# Altium schematic sheet VCU_Schematic.SchDoc(VCU_Schematic)
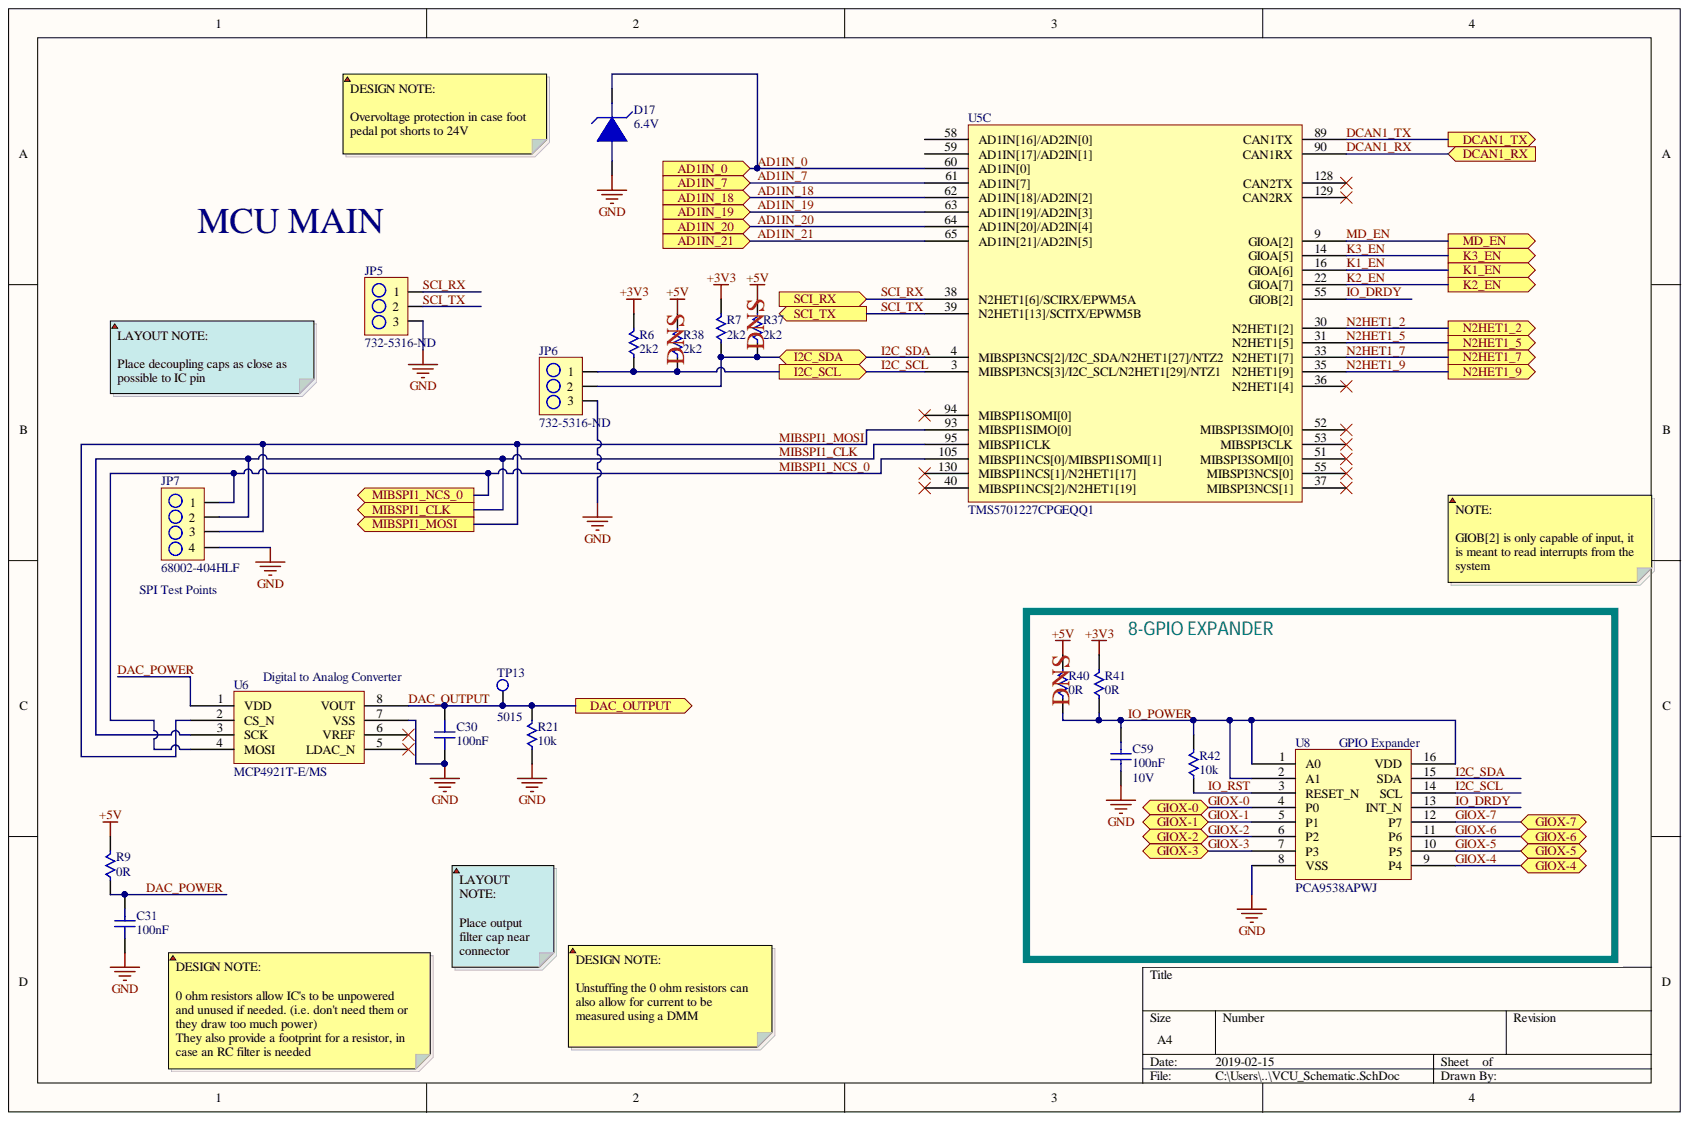
[diagram p0: VCU_Schematic.SchDoc]

## Parts
C30  100nF  [C0402]
C31  100nF  [C0402]
C59  100nF  Voltage Rating (DC): 10V  [C0402]
D17  6.4V  [DIODE_TVS]
JP5  732-5316-ND  [3PIN_HEADER]
JP6  732-5316-ND  [3PIN_HEADER]
JP7  68002-404HLF  [4PIN_HEADER]
R6  2k2  [R0402]
R7  2k2  [R0402]
R9  0R  [R0402]
R21  10k  [R0402]
R37  2k2  [R0402]
R38  2k2  [R0402]
R40  0R  [R0402]
R41  0R  [R0402]
R42  10k  [R0402]
TP13  5015  [TP_HOOK]
U5  TMS5701227CPGEQQ1  [LQFP-144]  (part C)
U6  MCP4921T-E/MS  [8-MSOP_MCP4921]
U8  PCA9538APWJ  [TSSOP-16]

## Ports
AD1IN_0, AD1IN_7, AD1IN_18, AD1IN_19, AD1IN_20, AD1IN_21, DAC_OUTPUT, DCAN1_RX, DCAN1_TX, GIOX-0, GIOX-1, GIOX-2, GIOX-3, GIOX-4, GIOX-5, GIOX-6, GIOX-7, I2C_SCL, I2C_SDA, K1_EN, K2_EN, K3_EN, MD_EN, MIBSPI1_CLK, MIBSPI1_MOSI, MIBSPI1_NCS_0, N2HET1_2, N2HET1_5, N2HET1_7, N2HET1_9, SCI_RX, SCI_TX

## Nets
+3V3: R6.2 R7.2 R41.2
+5V: R9.2 R37.2 R38.2 R40.2
GND: C30.1 C31.1 C59.1 D17.2 JP5.3 JP6.3 JP7.4 R21.1 U6.7(VSS) U8.8(VSS)
AD1IN_0: D17.1 U5.60(AD1IN[0]) ; port AD1IN_0
AD1IN_7: U5.61(AD1IN[7]) ; port AD1IN_7
AD1IN_18: U5.62(AD1IN[18]/AD2IN[2]) ; port AD1IN_18
AD1IN_19: U5.63(AD1IN[19]/AD2IN[3]) ; port AD1IN_19
AD1IN_20: U5.64(AD1IN[20]/AD2IN[4]) ; port AD1IN_20
AD1IN_21: U5.65(AD1IN[21]/AD2IN[5]) ; port AD1IN_21
DAC_OUTPUT: C30.2 R21.2 TP13.1 U6.8(VOUT) ; port DAC_OUTPUT
DAC_POWER: C31.2 R9.1 U6.1(VDD)
DCAN1_RX: U5.90(CAN1RX) ; port DCAN1_RX
DCAN1_TX: U5.89(CAN1TX) ; port DCAN1_TX
GIOX-0: U8.4(P0) ; port GIOX-0
GIOX-1: U8.5(P1) ; port GIOX-1
GIOX-2: U8.6(P2) ; port GIOX-2
GIOX-3: U8.7(P3) ; port GIOX-3
GIOX-4: U8.9(P4) ; port GIOX-4
GIOX-5: U8.10(P5) ; port GIOX-5
GIOX-6: U8.11(P6) ; port GIOX-6
GIOX-7: U8.12(P7) ; port GIOX-7
I2C_SCL: JP6.1 R6.1 R38.1 U5.3(MIBSPI3NCS[3]/I2C_SCL/N2HET1[29]/NTZ1) U8.14(SCL) ; port I2C_SCL
I2C_SDA: JP6.2 R7.1 R37.1 U5.4(MIBSPI3NCS[2]/I2C_SDA/N2HET1[27]/NTZ2) U8.15(SDA) ; port I2C_SDA
IO_DRDY: U5.55 U8.13(INT_N)
IO_POWER: C59.2 R40.1 R41.1 R42.2 U8.1(A0) U8.2(A1) U8.16(VDD)
IO_RST: R42.1 U8.3(RESET_N)
K1_EN: U5.16(GIOA[6]) ; port K1_EN
K2_EN: U5.22(GIOA[7]) ; port K2_EN
K3_EN: U5.14(GIOA[5]) ; port K3_EN
MD_EN: U5.9(GIOA[2]) ; port MD_EN
MIBSPI1_CLK: JP7.2 U5.95(MIBSPI1CLK) U6.3(SCK) ; port MIBSPI1_CLK
MIBSPI1_MOSI: JP7.3 U5.93(MIBSPI1SIMO[0]) U6.2(CS_N) ; port MIBSPI1_MOSI
MIBSPI1_NCS_0: JP7.1 U5.105(MIBSPI1NCS[0]/MIBSPI1SOMI[1]) U6.4(MOSI) ; port MIBSPI1_NCS_0
N2HET1_2: U5.30(N2HET1[2]) ; port N2HET1_2
N2HET1_5: U5.31(N2HET1[5]) ; port N2HET1_5
N2HET1_7: U5.33(N2HET1[7]) ; port N2HET1_7
N2HET1_9: U5.35(N2HET1[9]) ; port N2HET1_9
SCI_RX: JP5.1 U5.38(N2HET1[6]/SCIRX/EPWM5A) ; port SCI_RX
SCI_TX: JP5.2 U5.39(N2HET1[13]/SCITX/EPWM5B) ; port SCI_TX

Not connected: U5 36(N2HET1[4]) 37(MIBSPI3NCS[1]) 40(MIBSPI1NCS[2]/N2HET1[19]) 51(MIBSPI3SOMI[0]) 52(MIBSPI3SIMO[0]) 53(MIBSPI3CLK) 58(AD1IN[16]/AD2IN[0]) 59(AD1IN[17]/AD2IN[1]) 94(MIBSPI1SOMI[0]) 128(CAN2TX) 129(CAN2RX) 130(MIBSPI1NCS[1]/N2HET1[17]); U6 5(LDAC_N) 6(VREF)

## Library data of the parts
C30 C31 C59 = CL05A104MP5NNNC: CAP MLCC 0.1uF 10V X5R 0402 20% SMD 0402 85C Paper T/R; Manufacturer: Samsung; Width: 0.02inch; Voltage Rating: 10V; Tolerance: 20%; Thickness: 0.022inch; Supplier Part Number 1: 1276-1443-1-ND; Supplier 1: Digi-Key; Stock 1: 100000; Radiation Hardening: No; Pricing 1: 1=0.1, 10=0.024, 100=0.0106, 500=0.0076, 1000=0.006, 2500=0.0054, 5000=0.005 (USD); Packaging: Tape and Reel; Mount: Surface Mount; Min Operating Temperature: -55°C; Max Operating Temperature: 85°C; Manufacturer Part Number: CL05A104MP5NNNC; Length: 1mm; Lead Free: Lead Free; Height: 0.5mm; Dielectric: X5R; Depth: 0.5mm; ComponentLink1URL: https://media.digikey.com/pdf/Data%20Sheets/Samsung%20PDFs/CL_Series_MLCC_ds.pdf; ComponentLink1Description: https://media.digikey.com/pdf/Data%20Sheets/Samsung%20PDFs/CL_Series_MLCC_ds.pdf; Component Kind: Standard; Case Code (Metric): 1005; Case Code (Imperial): 0402; Case/Package: 0402; Capacitance: 100nF; Library Name: PHANTOM_LIBRARY.SchLib
  C30 C31: Voltage Rating (DC): 10V
D17 = SMAJ5.0A: DIODE TVS_6V4 5VWM 9.2VC SMA; Manufacturer: Littelfuse; Voltage Rating (DC): 5V; Test Current: 10mA; Power Line Protection: No; Power Dissipation: 400W; Polarity: Unidirectional; Peak Pulse Current: 43.5A; Number of Unidirectional Channels: 1; Max Reverse Leakage Current: 800uA; Max Power Dissipation: 3.3W; Max Breakdown Voltage: 7V; Element Configuration: Single; Clamping Voltage: 9.2V; Width: 2.79mm; Termination: SMD/SMT; Supplier Part Number 1: SMAJ5.0ALFCT-ND; Supplier 1: Digi-Key; Stock 1: 78251; Reverse Standoff Voltage: 5V; Reverse Breakdown Voltage: 6.4V; REACH SVHC: No SVHC; Radiation Hardening: No; Pricing 1: 1=0.51, 10=0.359, 25=0.2948, 100=0.2359, 250=0.1716, 500=0.1394, 1000=0.1072, 2500=0.0965 (USD); Peak Pulse Power: 400W; Packaging: Tape and Reel; Package Quantity: 5000; Operating Supply Voltage: 5V; Number of Pins: 2; Number of Elements: 1; Number of Channels: 1; Mount: Surface Mount; Min Operating Temperature: -65°C; Min Breakdown Voltage: 6.4V; Max Surge Current: 43.5A; Max Operating Temperature: 150°C; Manufacturer Part Number: SMAJ5.0A; Length: 4.5mm; Leakage Current: 800uA; Lead Free: Lead Free; Height: 2.087mm; Direction: Unidirectional; ComponentLink8URL: https://datasheet.ciiva.com/8062/littelfuse-tvs-diode-smaj-8062271.pdf; ComponentLink8Description: https://datasheet.ciiva.com/8062/littelfuse-tvs-diode-smaj-8062271.pdf; ComponentLink7URL: https://datasheet.ciiva.com/4507/littelfuse-tvs-diode-smaj-23503-4507964.pdf; ComponentLink7Description: https://datasheet.ciiva.com/4507/littelfuse-tvs-diode-smaj-23503-4507964.pdf; ComponentLink6URL: https://datasheet.ciiva.com/28473/607617-28473433.pdf; ComponentLink6Description: https://datasheet.ciiva.com/28473/607617-28473433.pdf; ComponentLink5URL: https://datasheet.ciiva.com/25624/0900766b810f4edb-25624839.pdf; ComponentLink5Description: https://datasheet.ciiva.com/25624/0900766b810f4edb-25624839.pdf; ComponentLink4URL: https://datasheet.ciiva.com/16380/littelfuse-tvs-diode-smaj-16380982.pdf; ComponentLink4Description: https://datasheet.ciiva.com/16380/littelfuse-tvs-diode-smaj-16380982.pdf; ComponentLink3URL: https://datasheet.ciiva.com/330/littelfuse-tvs-diode-smaj-datasheet-pdf-330726.pdf; ComponentLink3Description: https://datasheet.ciiva.com/330/littelfuse-tvs-diode-smaj-datasheet-pdf-330726.pdf; ComponentLink2URL: https://datasheet.ciiva.com/330/littelfuse-tvs-diode-smaj-datasheet-pdf-330725.pdf; ComponentLink2Description: https://datasheet.ciiva.com/330/littelfuse-tvs-diode-smaj-datasheet-pdf-330725.pdf; ComponentLink1URL: https://datasheet.ciiva.com/330/littelfuse-tvs-diode-smaj-datasheet-pdf-330724.pdf; ComponentLink1Description: https://datasheet.ciiva.com/330/littelfuse-tvs-diode-smaj-datasheet-pdf-330724.pdf; Component Kind: Standard; Breakdown Voltage: 6.4V; Library Name: PHANTOM_LIBRARY.SchLib
JP5 JP6 = 61300311121: CONN_HeaderPins3pos WURTH ELEKTRONIK Board-To-Board Connector, Vertical, WR-PHD Series, Through Hole, Header, 3 Contacts, 2.54 mm; Manufacturer: Wurth Electronics; Pitch: 0.1inch; Number of Positions: 3; Gender: Male; Connector Type: Male Pin; Termination: Solder; Supplier Part Number 1: 732-5316-ND; Supplier 1: Digi-Key; Stock 1: 57026; REACH SVHC: Unknown; Pricing 1: 1=0.13, 10=0.106, 25=0.088, 50=0.074, 100=0.067, 1000=0.061 (USD); Packaging: Bulk; Number of Rows: 1; Number of Contacts: 3; Mount: Through Hole; Manufacturer Part Number: 61300311121; Lead Free: Lead Free; Contact Plating: Gold; Contact Mating Length: 0.236inch; Contact Material: Copper; ComponentLink1URL: http://www.farnell.com/cad/1737268.pdf; ComponentLink1Description: http://www.farnell.com/cad/1737268.pdf; Component Kind: Standard; Colour: Black; Case/Package: 100; Library Name: PHANTOM_LIBRARY.SchLib
JP7 = 68002-404HLF: CONN_HeaderPins4pos 4 Positions Header, Unshrouded Connector 0.100" (2.54mm) Through Hole Tin; Manufacturer: Amphenol FCI; Voltage Rating: 1.5kV; Number of Positions: 4; Mating Post Length: 5.84mm; Insulation Resistance: 5GR; Gender: Male; Current Rating: 3A; Connector Type: Male Pin; Termination Post Length: 3.81mm; Termination: Solder; Supplier Part Number 1: 609-3305-ND; Supplier 1: Digi-Key; Stock 1: 4956; Radiation Hardening: No; Pricing 1: 1=0.21, 10=0.195, 100=0.1352, 500=0.1144, 1000=0.0936, 5000=0.0832, 10000=0.0754 (USD); Pitch: 2.54mm; Packaging: Bulk; Package Quantity: 100; Orientation: Straight; Number of Rows: 1; Number of Contacts: 4; Mount: Through Hole; Min Operating Temperature: -65°C; Max Voltage Rating (AC): 1.5kV; Max Operating Temperature: 125°C; Manufacturer Part Number: 68002-404HLF; Length: 10.16mm; Housing Material: Thermoplastic; Housing Colour: Black; Flammability Rating: UL94 V-0; Depth: 2.41mm; Contact Plating: Nickel,Tin; Contact Mating Length: 0.23inch; Contact Material: Bronze; Contact Gender: Male; ComponentLink3URL: https://datasheet.ciiva.com/728/68000-728877.pdf; ComponentLink3Description: https://datasheet.ciiva.com/728/68000-728877.pdf; ComponentLink2URL: https://datasheet.ciiva.com/16725/68000-16725993.pdf; ComponentLink2Description: https://datasheet.ciiva.com/16725/68000-16725993.pdf; ComponentLink1URL: https://datasheet.ciiva.com/9474/68000-9474358.pdf; ComponentLink1Description: https://datasheet.ciiva.com/9474/68000-9474358.pdf; Component Kind: Standard; Colour: Black; Library Name: PHANTOM_LIBRARY.SchLib
R6 R7 R37 R38 = RC0402JR-072K2L: RES SMD 2.2K OHM 5% 1/16W 0402; Manufacturer: Yageo; Working Voltage: 50V; Temperature Coefficient: 100ppm/°C; Resistance: 2.2kR; Power Rating: 63mW; Width: 0.02inch; Weight: 2.3E-05oz; Voltage Rating: 50V; Tolerance: 5%; Termination: SMD/SMT; Supplier Part Number 1: 311-2.2KJRCT-ND; Supplier 1: Digi-Key; Stock 1: 7890813; REACH SVHC: Unknown; Pricing 1: 1=0.1, 10=0.018, 100=0.0073, 1000=0.0033, 2500=0.0029, 5000=0.0024 (USD); Packaging: Tape and Reel; Package Quantity: 1; Number of Terminations: 2; Min Operating Temperature: -55°C; Military Standard: Not; Max Power Dissipation: 63mW; Max Operating Temperature: 155°C; Manufacturer Part Number: RC0402JR-072K2L; Length: 1mm; Lead Free: Lead Free; Height: 0.016inch; Features: Moisture Resistant; Depth: 0.5mm; Composition: Thick Film; Component Kind: Standard; Case Code (Metric): 1005; Case Code (Imperial): 0402; Case/Package: 0402; Library Name: PHANTOM_LIBRARY.SchLib
R9 R40 R41 = RC0402JR-070RL: RES SMD 0 OHM 1/16W 0402; Manufacturer: Yageo; Voltage Rating: 50V; Tolerance: 5%; Temperature Coefficient: 200ppm/°C; Resistance: 0mR; Power Rating: 62.5mW; Max Power Dissipation: 63mW; Width: 0.02inch; Termination: SMD/SMT; Supplier Part Number 1: 311-0.0JRCT-ND; Supplier 1: Digi-Key; Stock 1: 44333412; REACH SVHC: Unknown; Radiation Hardening: No; Pricing 1: 1=0.1, 10=0.017, 25=0.0124, 50=0.0096, 100=0.007, 250=0.0054, 500=0.0043, 1000=0.0032, 5000=0.0023 (USD); Packaging: Tape and Reel; Package Quantity: 1; Number of Terminations: 2; Min Operating Temperature: -55°C; Military Standard: Not; Max Operating Temperature: 155°C; Manufacturer Part Number: RC0402JR-070RL; Length: 1mm; Lead Free: Lead Free; Height: 0.016inch; Features: Moisture Resistant; Depth: 0.5mm; Contact Plating: Matte,Tin; Composition: Thick Film; Component Kind: Standard; Case Code (Metric): 1005; Case Code (Imperial): 0402; Case/Package: 0402; Library Name: PHANTOM_LIBRARY.SchLib
R21 R42 = RC0402JR-0710KL: RES SMD 10K OHM 5% 1/16W 0402; Manufacturer: Yageo; Voltage Rating: 50V; Temperature Coefficient: 100ppm/°C; Resistance: 10kR; Power Rating: 62.5mW; Max Power Dissipation: 63mW; Width: 0.02inch; Tolerance: 5%; Termination: SMD/SMT; Supplier Part Number 1: 311-10KJRCT-ND; Supplier 1: Digi-Key; Stock 1: 47303622; REACH SVHC: Unknown; Pricing 1: 1=0.1, 10=0.018, 100=0.0073, 1000=0.0033, 2500=0.0029, 5000=0.0024 (USD); Packaging: Tape and Reel; Package Quantity: 1; Number of Terminations: 2; Min Operating Temperature: -55°C; Military Standard: Not; Max Operating Temperature: 155°C; Manufacturer Part Number: RC0402JR-0710KL; Length: 1mm; Lead Free: Lead Free; Height: 0.016inch; Features: Moisture Resistant; Depth: 0.5mm; Composition: Thick Film; Component Kind: Standard; Case Code (Metric): 1005; Case Code (Imperial): 0402; Case/Package: 0402; Library Name: PHANTOM_LIBRARY.SchLib
TP13 = 5015: TEST POINT, PCB, SMT; Manufacturer: Keystone Electronics; Number of Ports: 1; Thickness: 0.01inch; Termination: Solder; Supplier Part Number 1: 36-5015CT-ND; Supplier 1: Digi-Key; Stock 1: 1937775; REACH SVHC: No SVHC; Pricing 1: 1=0.44, 10=0.421, 25=0.3604, 50=0.3064, 100=0.2944, 250=0.2644, 500=0.2524 (USD); Packaging: Tape and Reel; Package Quantity: 1; Orientation: Straight; Mount: Surface Mount; Material: Bronze,Silver; Manufacturer Part Number: 5015; Length: 2.66mm; Lead Free: Lead Free; Height: 0.06inch; Depth: 1.01mm; Contact Plating: Tin,Silver,Lead; Contact Material: Bronze; ComponentLink4URL: https://datasheet.ciiva.com/16883/70182998-16883734.pdf; ComponentLink4Description: https://datasheet.ciiva.com/16883/70182998-16883734.pdf; ComponentLink3URL: https://datasheet.ciiva.com/1123/5015-1123862.pdf; ComponentLink3Description: https://datasheet.ciiva.com/1123/5015-1123862.pdf; ComponentLink2URL: https://datasheet.ciiva.com/2917/5015-2917617.pdf; ComponentLink2Description: https://datasheet.ciiva.com/2917/5015-2917617.pdf; ComponentLink1URL: https://datasheet.ciiva.com/2917/5015-2917616.pdf; ComponentLink1Description: https://datasheet.ciiva.com/2917/5015-2917616.pdf; Component Kind: Standard; Colour: White; Library Name: PHANTOM_LIBRARY.SchLib
U5 = TMS5701227CPGEQQ1: IC MCU 16-Bit/32-Bit Hercules TMS570 ARM Cortex R4F RISC 1280KB Flash 1.2V/3.3V 144-Pin LQFP; Manufacturer: Texas Instruments; Watchdog Timer: Yes; RAM Size: 192KB; Peripherals: DMA,POR,PWM,WDT; Oscillator Type: External; Number of Timers/Counters: 2; Number of Programmable I/O: 58; Memory Size: 1.25MB; Max Frequency: 160MHz; Interface: CAN,EBI/EMI,Ethernet,I2C,LIN,SCI,SPI,UART,USART; Density: 1310720B; Supplier Part Number 1: 296-42451-ND; Supplier 1: Digi-Key; Stock 1: 2872; Pricing 1: 1=24.99, 10=23.046, 60=22.0098, 120=19.6794, 540=17.8669 (USD); Packaging: Tray; Number of Pins: 144; Number of I/Os: 58; Number of GPIO: 58; Min Operating Temperature: -40°C; Memory Type: FLASH; Max Operating Temperature: 125°C; Manufacturer Part Number: TMS5701227CPGEQQ1; Lead Free: Lead Free; Frequency: 160MHz; Core Architecture: ARM; Component Kind: Standard; Case/Package: LQFP; Library Name: PHANTOM_LIBRARY.SchLib
U6 = MCP4921T-E/MS: IC Digital to Analog Converters - DAC Sgl 12-bit SPI int; Manufacturer: Microchip; Supply Voltage: 5V; Supply Type: Single; Settling Time: 4.5us; Resolution: 12b; Number of DAC Channels: 1; Number of Bits: 12; Nominal Supply Current: 175uA; Min Supply Voltage: 2.7V; Max Supply Voltage: 5.5V; Interface: SPI,Serial; Integral Nonlinearity (INL): 12LSB; Input Offset Voltage (Vos): 820uV; Supplier Part Number 1: MCP4921T-E/MSCT-ND; Supplier 1: Digi-Key; Stock 1: 5999; REACH SVHC: No SVHC; Radiation Hardening: No; Pricing 1: 1=2.07, 25=1.722, 100=1.5645 (USD); Packaging: Tape and Reel; Package Quantity: 1; Number of Pins: 8; Number of Converters: 1; Number of Channels: 1; Mount: Surface Mount; Min Operating Temperature: -40°C; Max Operating Temperature: 125°C; Manufacturer Part Number: MCP4921T-E/MS; Lead Free: Lead Free; ComponentLink7URL: https://datasheet.ciiva.com/41459/001083287-da-01-en-ic-dac-12-w-spi-mcp4921t-e-ms-msop-8-mcp-41459046.pdf; ComponentLink7Description: https://datasheet.ciiva.com/41459/001083287-da-01-en-ic-dac-12-w-spi-mcp4921t-e-ms-msop-8-mcp-41459046.pdf; ComponentLink6URL: https://datasheet.ciiva.com/6666/22248a-6666558.pdf; ComponentLink6Description: https://datasheet.ciiva.com/6666/22248a-6666558.pdf; ComponentLink5URL: https://datasheet.ciiva.com/16203/filehandler-aspxddocname-en547911-16203277.pdf; ComponentLink5Description: https://datasheet.ciiva.com/16203/filehandler-aspxddocname-en547911-16203277.pdf; ComponentLink4URL: https://datasheet.ciiva.com/16625/22248a-16625692.pdf; ComponentLink4Description: https://datasheet.ciiva.com/16625/22248a-16625692.pdf; ComponentLink3URL: https://datasheet.ciiva.com/8035/22248a-8035052.pdf; ComponentLink3Description: https://datasheet.ciiva.com/8035/22248a-8035052.pdf; ComponentLink2URL: https://datasheet.ciiva.com/6666/22248a-6666553.pdf; ComponentLink2Description: https://datasheet.ciiva.com/6666/22248a-6666553.pdf; ComponentLink1URL: https://datasheet.ciiva.com/3041/22248a-3041510.pdf; ComponentLink1Description: https://datasheet.ciiva.com/3041/22248a-3041510.pdf; Component Kind: Standard; Case/Package: MSOP; Library Name: PHANTOM_LIBRARY.SchLib
U8 = PCA9538APWJ: IC GPIO EXPANDER 8BIT 16TSSOP; Manufacturer: NXP Semiconductors; Power Dissipation: 200mW; Output Current: 25mA; Operating Supply Voltage: 5.5V; Number of I/Os: 8; Max Frequency: 100kHz; Interface: I2C,SMBus; Frequency: 400kHz; Supplier Part Number 1: 568-9915-1-ND; Supplier 1: Digi-Key; Stock 1: 4769; Pricing 1: 1=1.45, 10=1.298, 100=1.012, 500=0.836, 1000=0.66 (USD); Packaging: Tape and Reel; Package Quantity: 1; Number of Pins: 16; Mount: Surface Mount; Min Operating Temperature: -40°C; Max Operating Temperature: 85°C; Manufacturer Part Number: PCA9538APWJ; Lead Free: Lead Free; Contact Plating: Gold; ComponentLink3URL: https://www.nxp.com/docs/en/data-sheet/PCA9538A.pdf; ComponentLink3Description: https://www.nxp.com/docs/en/data-sheet/PCA9538A.pdf; ComponentLink2URL: http://www.nxp.com/documents/other/design_con_2003_tecforum_i2c_bus_overview.pdf; ComponentLink2Description: http://www.nxp.com/documents/other/design_con_2003_tecforum_i2c_bus_overview.pdf; ComponentLink1URL: http://www.mouser.com/ds/2/302/PCA9538A-841729.pdf; ComponentLink1Description: http://www.mouser.com/ds/2/302/PCA9538A-841729.pdf; Component Kind: Standard; Case/Package: TSSOP; Library Name: PHANTOM_LIBRARY.SchLib
